# Altium schematic sheet Sheet2.SchDoc
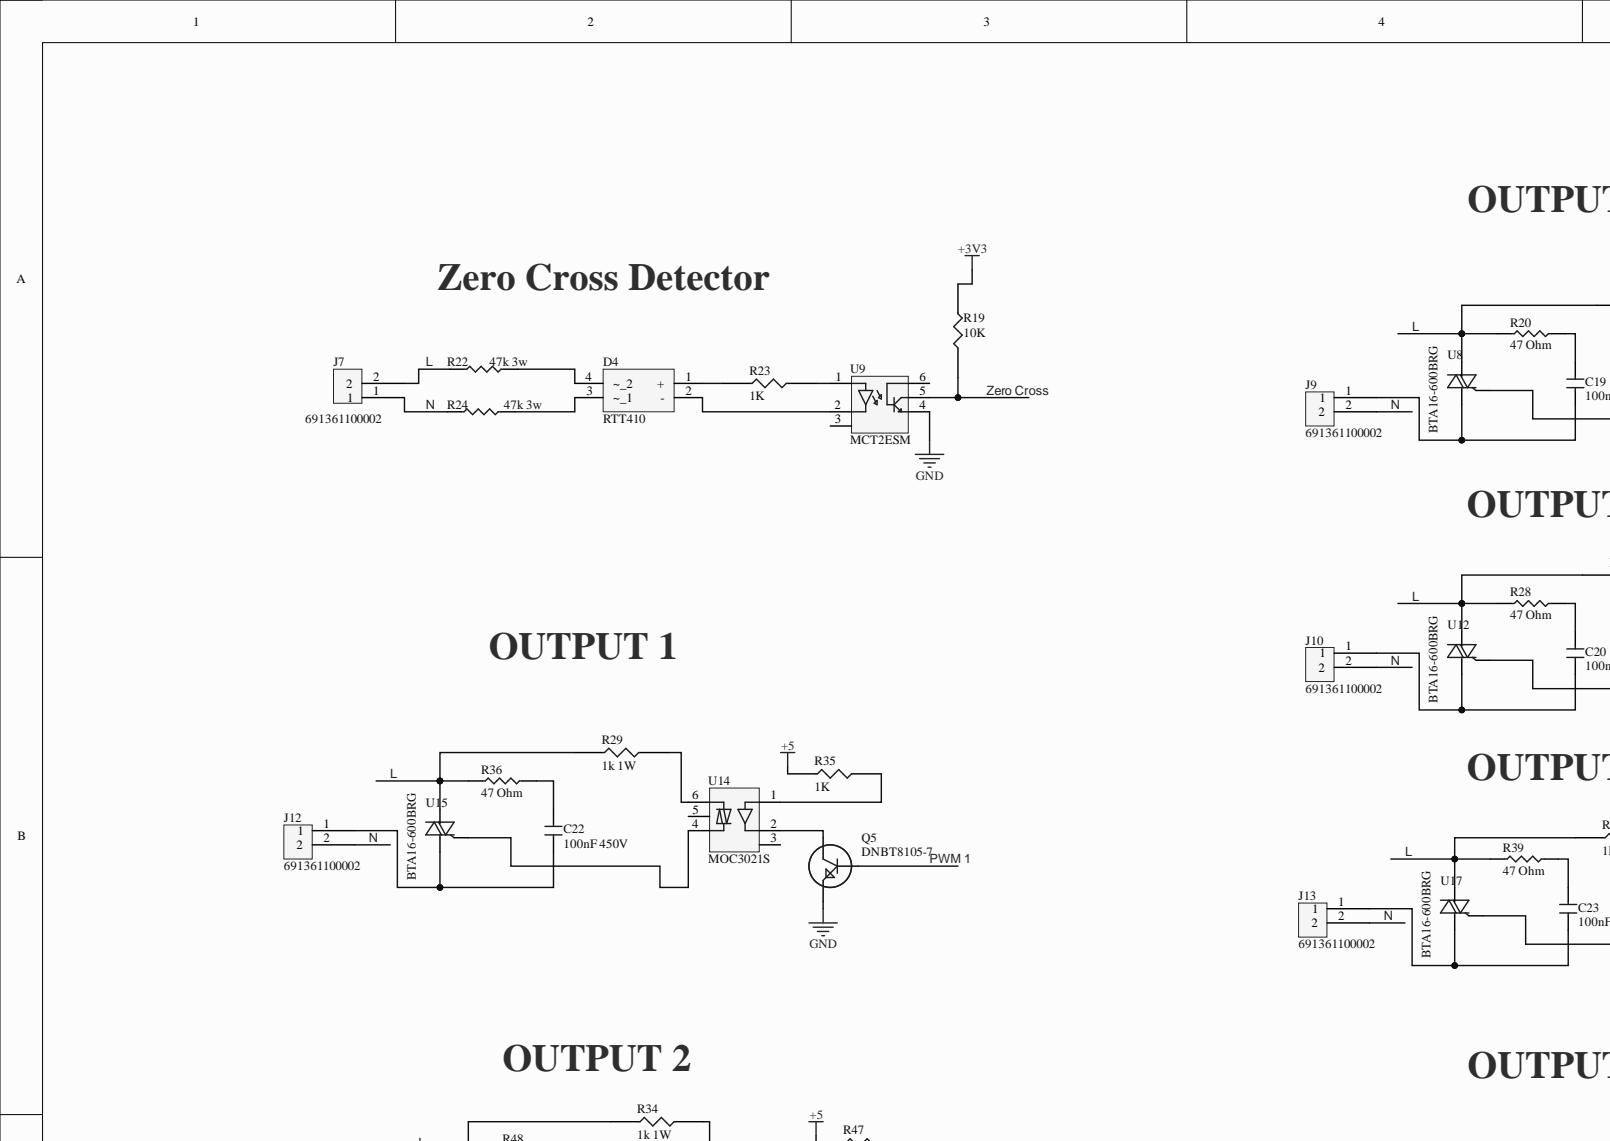
[diagram p0: Sheet2.SchDoc, top left]
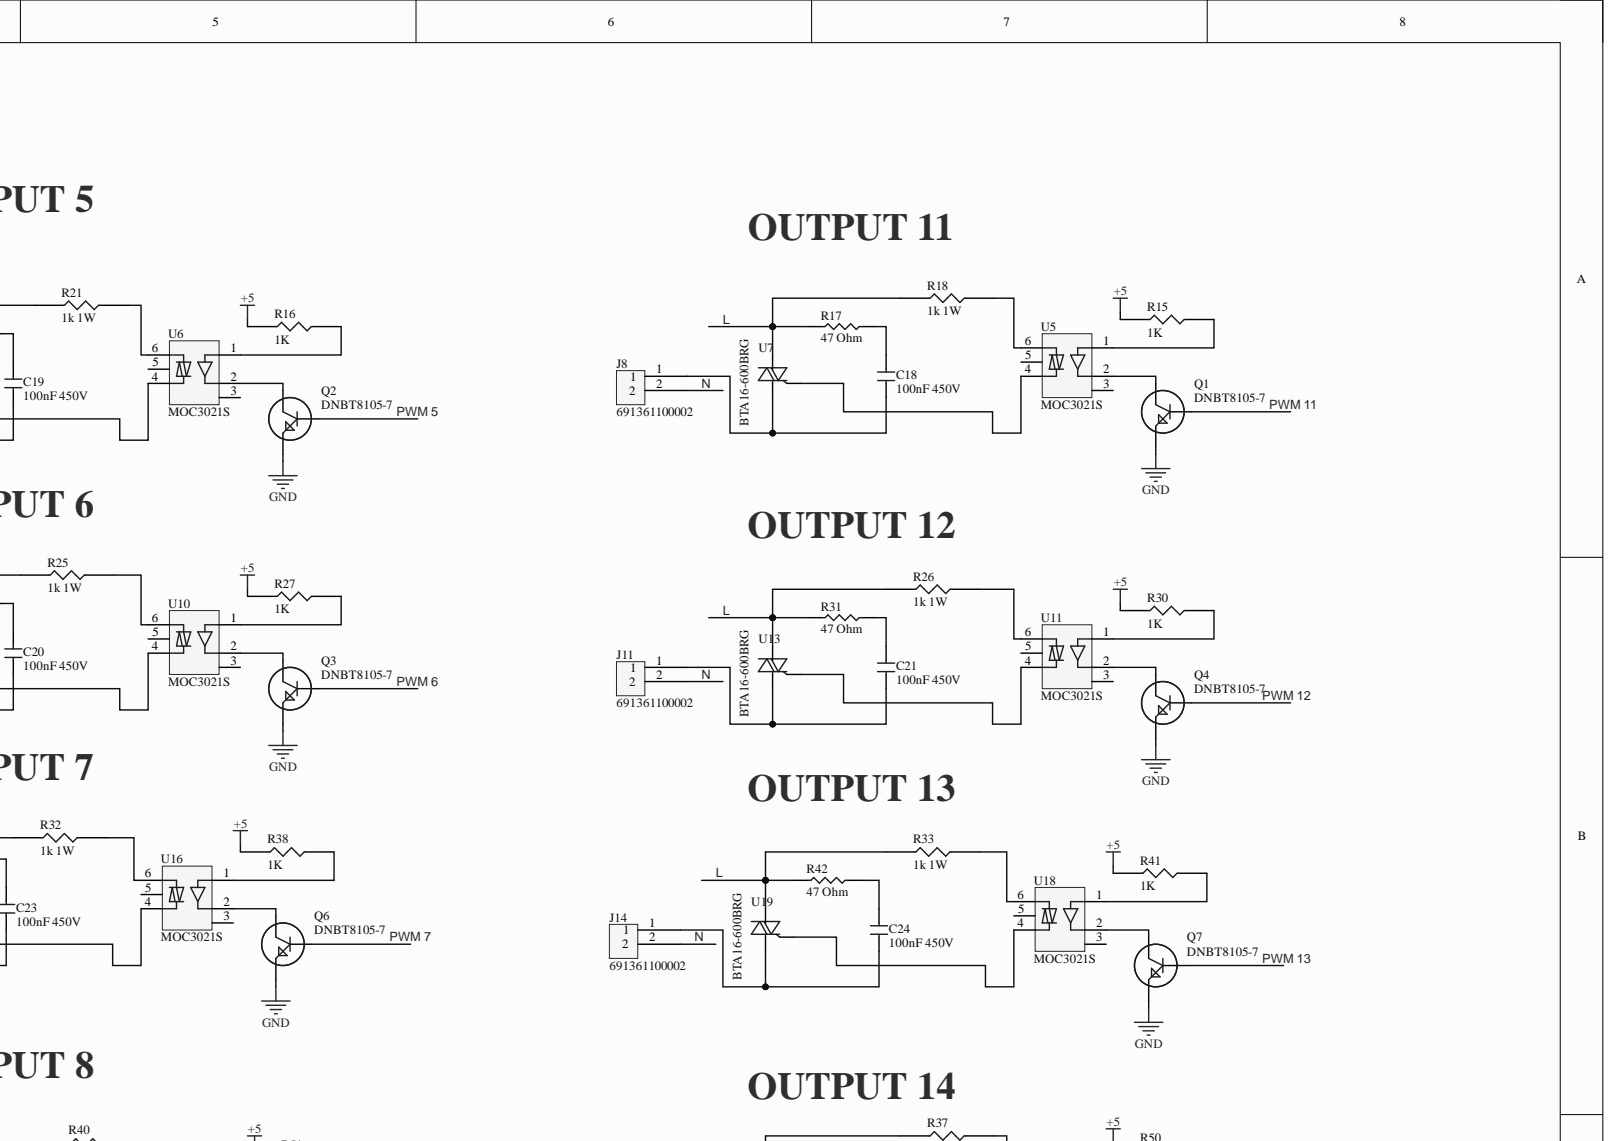
[diagram p1: Sheet2.SchDoc, top right]
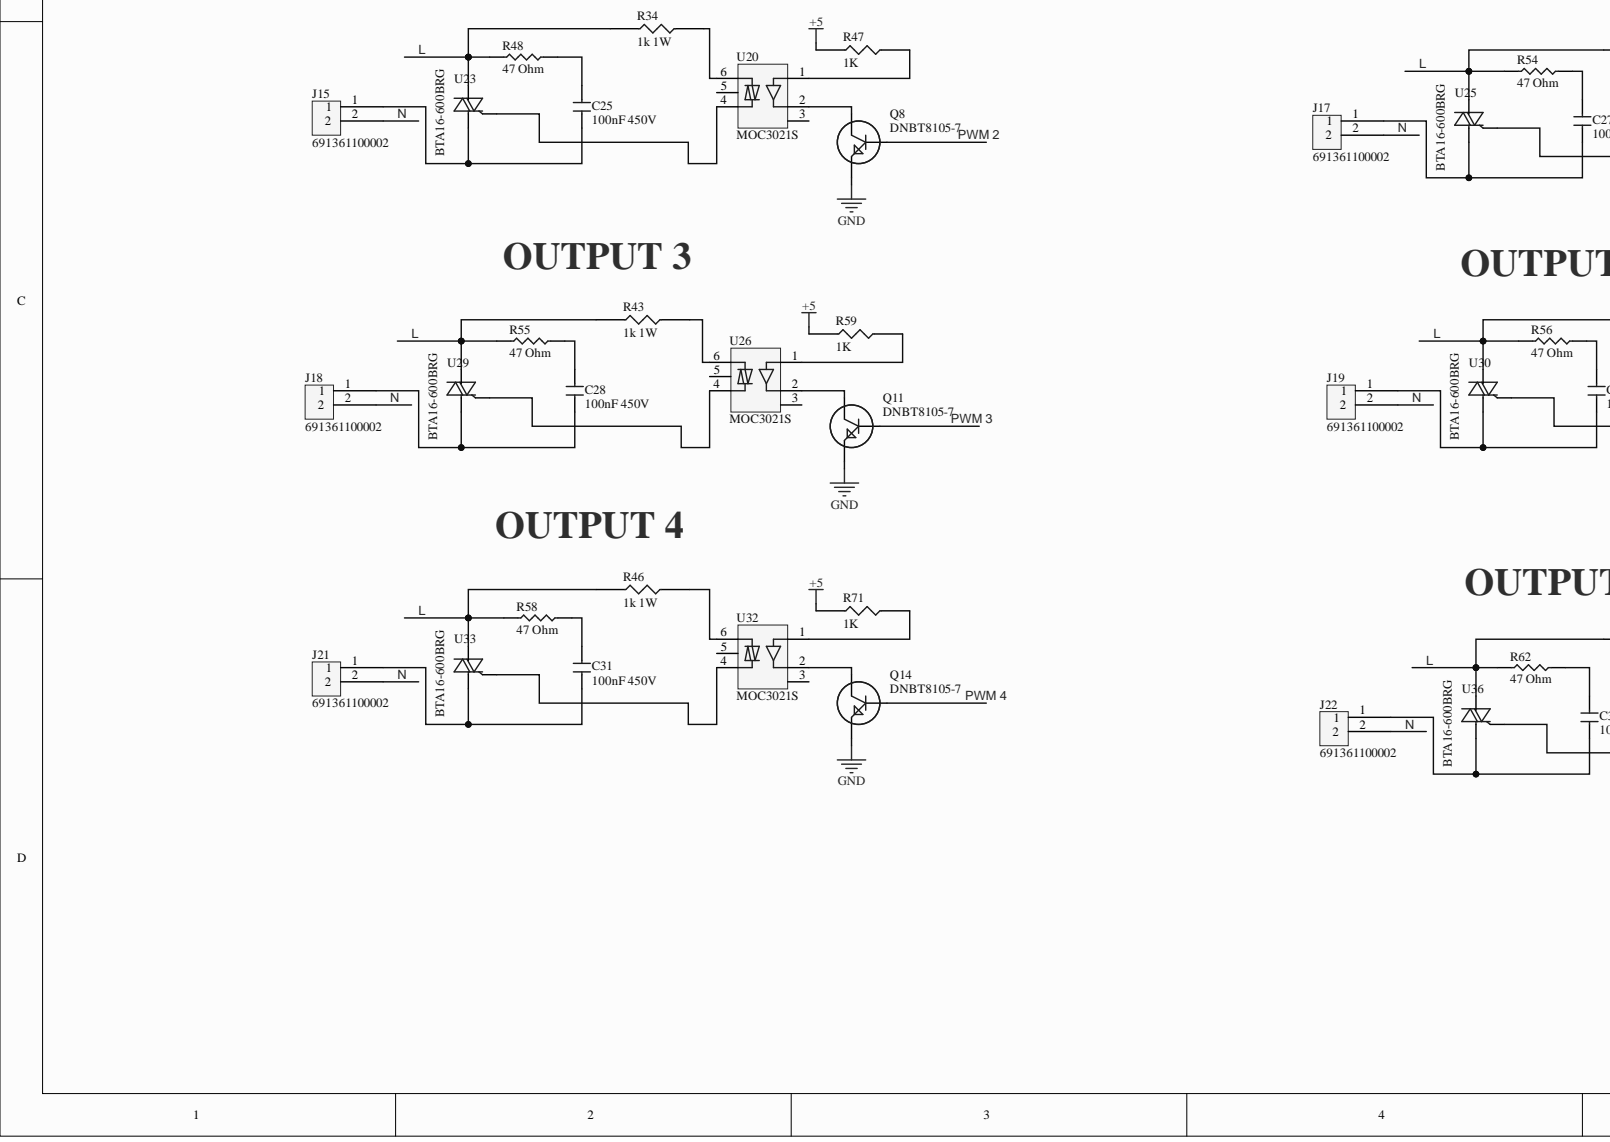
[diagram p2: Sheet2.SchDoc, bottom left]
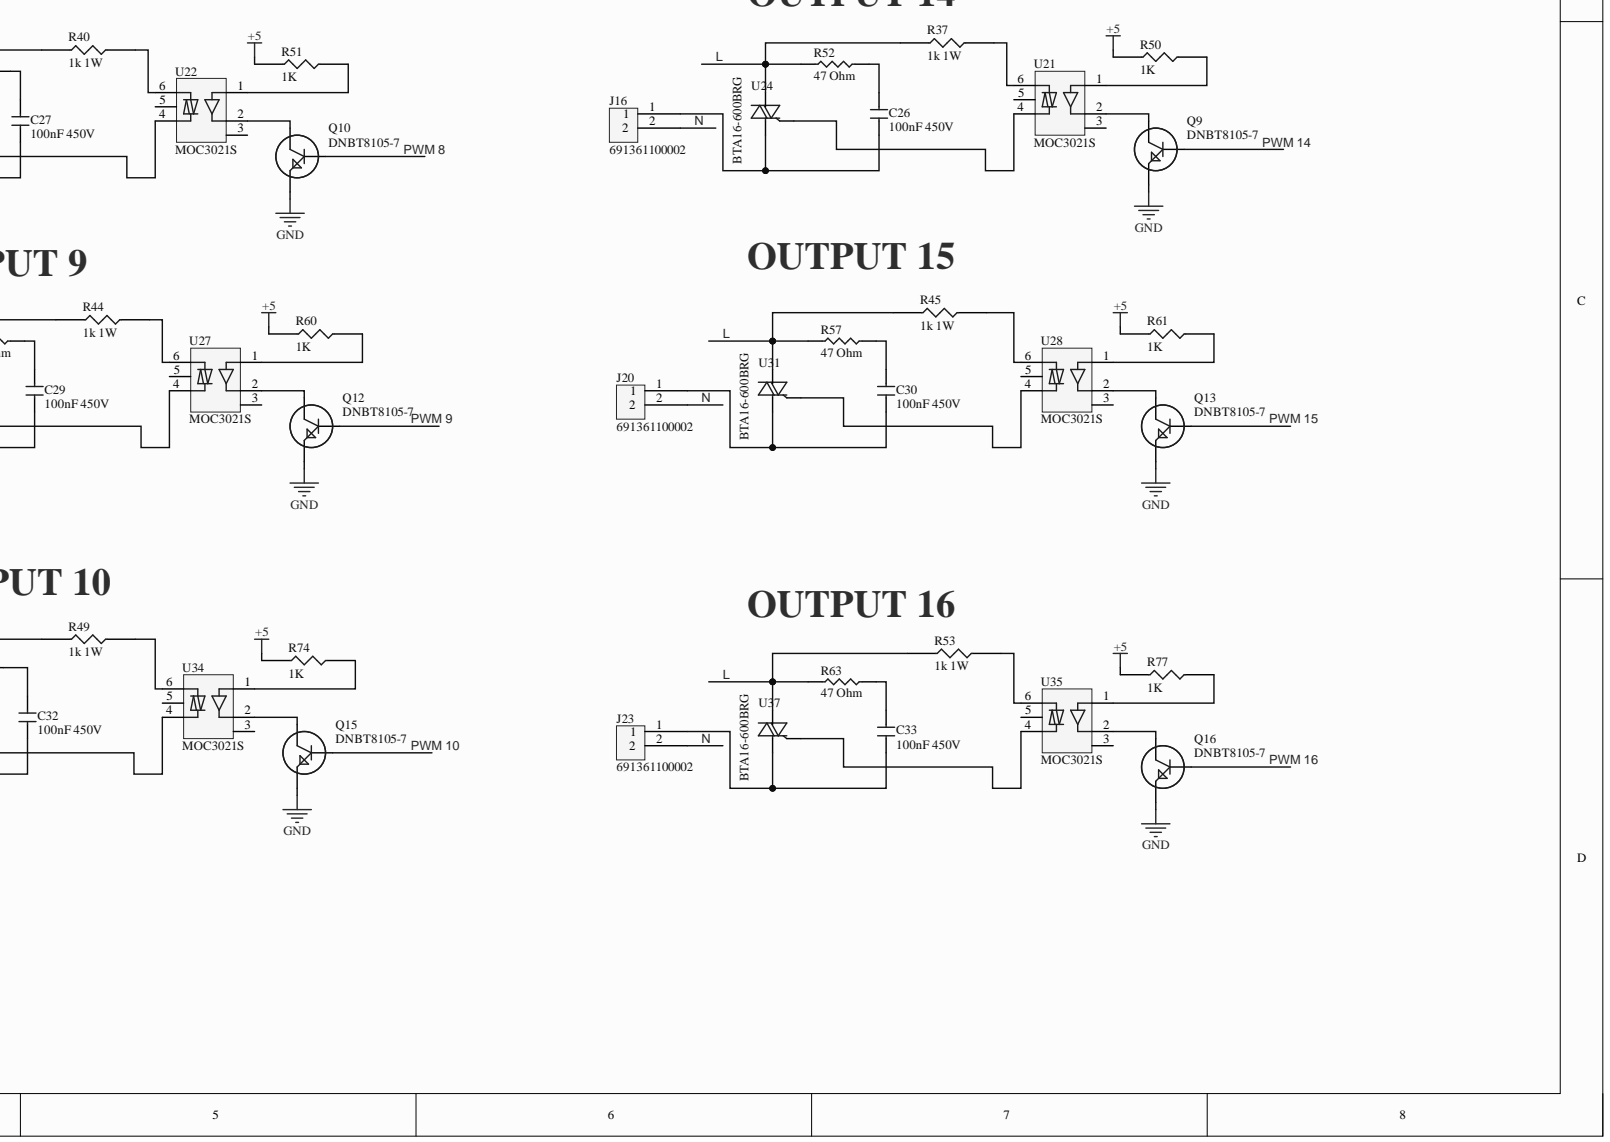
[diagram p3: Sheet2.SchDoc, bottom right]

## Parts
C18  100nF 450V  Capacitance: 100nF  Voltage Rating: 450V  [CAP 1206]
C19  100nF 450V  Capacitance: 100nF  Voltage Rating: 450V  [CAP 1206]
C20  100nF 450V  Capacitance: 100nF  Voltage Rating: 450V  [CAP 1206]
C21  100nF 450V  Capacitance: 100nF  Voltage Rating: 450V  [CAP 1206]
C22  100nF 450V  Capacitance: 100nF  Voltage Rating: 450V  [CAP 1206]
C23  100nF 450V  Capacitance: 100nF  Voltage Rating: 450V  [CAP 1206]
C24  100nF 450V  Capacitance: 100nF  Voltage Rating: 450V  [CAP 1206]
C25  100nF 450V  Capacitance: 100nF  Voltage Rating: 450V  [CAP 1206]
C26  100nF 450V  Capacitance: 100nF  Voltage Rating: 450V  [CAP 1206]
C27  100nF 450V  Capacitance: 100nF  Voltage Rating: 450V  [CAP 1206]
C28  100nF 450V  Capacitance: 100nF  Voltage Rating: 450V  [CAP 1206]
C29  100nF 450V  Capacitance: 100nF  Voltage Rating: 450V  [CAP 1206]
C30  100nF 450V  Capacitance: 100nF  Voltage Rating: 450V  [CAP 1206]
C31  100nF 450V  Capacitance: 100nF  Voltage Rating: 450V  [CAP 1206]
C32  100nF 450V  Capacitance: 100nF  Voltage Rating: 450V  [CAP 1206]
C33  100nF 450V  Capacitance: 100nF  Voltage Rating: 450V  [CAP 1206]
D4  RTT410  [RT410]
J7  691361100002  [Screw Terminal 3.5mm]
J8  691361100002  [Screw Terminal 3.5mm]
J9  691361100002  [Screw Terminal 3.5mm]
J10  691361100002  [Screw Terminal 3.5mm]
J11  691361100002  [Screw Terminal 3.5mm]
J12  691361100002  [Screw Terminal 3.5mm]
J13  691361100002  [Screw Terminal 3.5mm]
J14  691361100002  [Screw Terminal 3.5mm]
J15  691361100002  [Screw Terminal 3.5mm]
J16  691361100002  [Screw Terminal 3.5mm]
J17  691361100002  [Screw Terminal 3.5mm]
J18  691361100002  [Screw Terminal 3.5mm]
J19  691361100002  [Screw Terminal 3.5mm]
J20  691361100002  [Screw Terminal 3.5mm]
J21  691361100002  [Screw Terminal 3.5mm]
J22  691361100002  [Screw Terminal 3.5mm]
J23  691361100002  [Screw Terminal 3.5mm]
Q1  DNBT8105-7  [SOT 223 3]
Q2  DNBT8105-7  [SOT 223 3]
Q3  DNBT8105-7  [SOT 223 3]
Q4  DNBT8105-7  [SOT 223 3]
Q5  DNBT8105-7  [SOT 223 3]
Q6  DNBT8105-7  [SOT 223 3]
Q7  DNBT8105-7  [SOT 223 3]
Q8  DNBT8105-7  [SOT 223 3]
Q9  DNBT8105-7  [SOT 223 3]
Q10  DNBT8105-7  [SOT 223 3]
Q11  DNBT8105-7  [SOT 223 3]
Q12  DNBT8105-7  [SOT 223 3]
Q13  DNBT8105-7  [SOT 223 3]
Q14  DNBT8105-7  [SOT 223 3]
Q15  DNBT8105-7  [SOT 223 3]
Q16  DNBT8105-7  [SOT 223 3]
R15  1K  [R_0805]
R16  1K  [R_0805]
R17  47 Ohm  [R 1206]
R18  1k 1W  [R_0805]
R19  10K  [R_0805]
R20  47 Ohm  [R 1206]
R21  1k 1W  [R_0805]
R22  47k 3w  [R 2512]
R23  1K  [R_0805]
R24  47k 3w  [R 2512]
R25  1k 1W  [R_0805]
R26  1k 1W  [R_0805]
R27  1K  [R_0805]
R28  47 Ohm  [R 1206]
R29  1k 1W  [R_0805]
R30  1K  [R_0805]
R31  47 Ohm  [R 1206]
R32  1k 1W  [R_0805]
R33  1k 1W  [R_0805]
R34  1k 1W  [R_0805]
R35  1K  [R_0805]
R36  47 Ohm  [R 1206]
R37  1k 1W  [R_0805]
R38  1K  [R_0805]
R39  47 Ohm  [R 1206]
R40  1k 1W  [R_0805]
R41  1K  [R_0805]
R42  47 Ohm  [R 1206]
R43  1k 1W  [R_0805]
R44  1k 1W  [R_0805]
R45  1k 1W  [R_0805]
R46  1k 1W  [R_0805]
R47  1K  [R_0805]
R48  47 Ohm  [R 1206]
R49  1k 1W  [R_0805]
R50  1K  [R_0805]
R51  1K  [R_0805]
R52  47 Ohm  [R 1206]
R53  1k 1W  [R_0805]
R54  47 Ohm  [R 1206]
R55  47 Ohm  [R 1206]
R56  47 Ohm  [R 1206]
R57  47 Ohm  [R 1206]
R58  47 Ohm  [R 1206]
R59  1K  [R_0805]
R60  1K  [R_0805]
R61  1K  [R_0805]
R62  47 Ohm  [R 1206]
R63  47 Ohm  [R 1206]
R71  1K  [R_0805]
R74  1K  [R_0805]
R77  1K  [R_0805]
U5  MOC3021S  [SMD 6]
U6  MOC3021S  [SMD 6]
U7  BTA16-600BRG  [BAT16]
U8  BTA16-600BRG  [BAT16]
U9  MCT2ESM  [SMD 6]
U10  MOC3021S  [SMD 6]
U11  MOC3021S  [SMD 6]
U12  BTA16-600BRG  [BAT16]
U13  BTA16-600BRG  [BAT16]
U14  MOC3021S  [SMD 6]
U15  BTA16-600BRG  [BAT16]
U16  MOC3021S  [SMD 6]
U17  BTA16-600BRG  [BAT16]
U18  MOC3021S  [SMD 6]
U19  BTA16-600BRG  [BAT16]
U20  MOC3021S  [SMD 6]
U21  MOC3021S  [SMD 6]
U22  MOC3021S  [SMD 6]
U23  BTA16-600BRG  [BAT16]
U24  BTA16-600BRG  [BAT16]
U25  BTA16-600BRG  [BAT16]
U26  MOC3021S  [SMD 6]
U27  MOC3021S  [SMD 6]
U28  MOC3021S  [SMD 6]
U29  BTA16-600BRG  [BAT16]
U30  BTA16-600BRG  [BAT16]
U31  BTA16-600BRG  [BAT16]
U32  MOC3021S  [SMD 6]
U33  BTA16-600BRG  [BAT16]
U34  MOC3021S  [SMD 6]
U35  MOC3021S  [SMD 6]
U36  BTA16-600BRG  [BAT16]
U37  BTA16-600BRG  [BAT16]

## Nets
+3V3: R19.1
+5: R15.2 R16.2 R27.2 R30.2 R35.2 R38.2 R41.2 R47.2 R50.2 R51.2 R59.2 R60.2 R61.2 R71.2 R74.2 R77.2
GND: Q1.2 Q2.2 Q3.2 Q4.2 Q5.2 Q6.2 Q7.2 Q8.2 Q9.2 Q10.2 Q11.2 Q12.2 Q13.2 Q14.2 Q15.2 Q16.2 U9.4
L: J7.2 R17.1 R18.1 R20.1 R21.1 R22.1 R25.1 R26.1 R28.1 R29.1 R31.1 R32.1 R33.1 R34.1 R36.1 R37.1 R39.1 R40.1 R42.1 R43.1 R44.1 R45.1 R46.1 R48.1 R49.1 R52.1 R53.1 R54.1 R55.1 R56.1 R57.1 R58.1 R62.1 R63.1 U7.2 U8.2 U12.2 U13.2 U15.2 U17.2 U19.2 U23.2 U24.2 U25.2 U29.2 U30.2 U31.2 U33.2 U36.2 U37.2
N: J7.1 J8.2 J9.2 J10.2 J11.2 J12.2 J13.2 J14.2 J15.2 J16.2 J17.2 J18.2 J19.2 J20.2 J21.2 J22.2 J23.2 R24.1
PWM 1: Q5.1
PWM 2: Q8.1
PWM 3: Q11.1
PWM 4: Q14.1
PWM 5: Q2.1
PWM 6: Q3.1
PWM 7: Q6.1
PWM 8: Q10.1
PWM 9: Q12.1
PWM 10: Q15.1
PWM 11: Q1.1
PWM 12: Q4.1
PWM 13: Q7.1
PWM 14: Q9.1
PWM 15: Q13.1
PWM 16: Q16.1
Zero Cross: R19.2 U9.5
NetC18_1: C18.1 J8.1 U7.1
NetC18_2: C18.2 R17.2
NetC19_1: C19.1 J9.1 U8.1
NetC19_2: C19.2 R20.2
NetC20_1: C20.1 J10.1 U12.1
NetC20_2: C20.2 R28.2
NetC21_1: C21.1 J11.1 U13.1
NetC21_2: C21.2 R31.2
NetC22_1: C22.1 J12.1 U15.1
NetC22_2: C22.2 R36.2
NetC23_1: C23.1 J13.1 U17.1
NetC23_2: C23.2 R39.2
NetC24_1: C24.1 J14.1 U19.1
NetC24_2: C24.2 R42.2
NetC25_1: C25.1 J15.1 U23.1
NetC25_2: C25.2 R48.2
NetC26_1: C26.1 J16.1 U24.1
NetC26_2: C26.2 R52.2
NetC27_1: C27.1 J17.1 U25.1
NetC27_2: C27.2 R54.2
NetC28_1: C28.1 J18.1 U29.1
NetC28_2: C28.2 R55.2
NetC29_1: C29.1 J19.1 U30.1
NetC29_2: C29.2 R56.2
NetC30_1: C30.1 J20.1 U31.1
NetC30_2: C30.2 R57.2
NetC31_1: C31.1 J21.1 U33.1
NetC31_2: C31.2 R58.2
NetC32_1: C32.1 J22.1 U36.1
NetC32_2: C32.2 R62.2
NetC33_1: C33.1 J23.1 U37.1
NetC33_2: C33.2 R63.2
NetD4_1: D4.1(+) R23.1
NetD4_2: D4.2(-) U9.2
NetD4_3: D4.3(~_1) R24.2
NetD4_4: D4.4(~_2) R22.2
NetQ1_3: Q1.3 U5.2
NetQ2_3: Q2.3 U6.2
NetQ3_3: Q3.3 U10.2
NetQ4_3: Q4.3 U11.2
NetQ5_3: Q5.3 U14.2
NetQ6_3: Q6.3 U16.2
NetQ7_3: Q7.3 U18.2
NetQ8_3: Q8.3 U20.2
NetQ9_3: Q9.3 U21.2
NetQ10_3: Q10.3 U22.2
NetQ11_3: Q11.3 U26.2
NetQ12_3: Q12.3 U27.2
NetQ13_3: Q13.3 U28.2
NetQ14_3: Q14.3 U32.2
NetQ15_3: Q15.3 U34.2
NetQ16_3: Q16.3 U35.2
NetR15_1: R15.1 U5.1
NetR16_1: R16.1 U6.1
NetR18_2: R18.2 U5.6
NetR21_2: R21.2 U6.6
NetR23_2: R23.2 U9.1
NetR25_2: R25.2 U10.6
NetR26_2: R26.2 U11.6
NetR27_1: R27.1 U10.1
NetR29_2: R29.2 U14.6
NetR30_1: R30.1 U11.1
NetR32_2: R32.2 U16.6
NetR33_2: R33.2 U18.6
NetR34_2: R34.2 U20.6
NetR35_1: R35.1 U14.1
NetR37_2: R37.2 U21.6
NetR38_1: R38.1 U16.1
NetR40_2: R40.2 U22.6
NetR41_1: R41.1 U18.1
NetR43_2: R43.2 U26.6
NetR44_2: R44.2 U27.6
NetR45_2: R45.2 U28.6
NetR46_2: R46.2 U32.6
NetR47_1: R47.1 U20.1
NetR49_2: R49.2 U34.6
NetR50_1: R50.1 U21.1
NetR51_1: R51.1 U22.1
NetR53_2: R53.2 U35.6
NetR59_1: R59.1 U26.1
NetR60_1: R60.1 U27.1
NetR61_1: R61.1 U28.1
NetR71_1: R71.1 U32.1
NetR74_1: R74.1 U34.1
NetR77_1: R77.1 U35.1
NetU5_4: U5.4 U7.3
NetU6_4: U6.4 U8.3
NetU10_4: U10.4 U12.3
NetU11_4: U11.4 U13.3
NetU14_4: U14.4 U15.3
NetU16_4: U16.4 U17.3
NetU18_4: U18.4 U19.3
NetU20_4: U20.4 U23.3
NetU21_4: U21.4 U24.3
NetU22_4: U22.4 U25.3
NetU26_4: U26.4 U29.3
NetU27_4: U27.4 U30.3
NetU28_4: U28.4 U31.3
NetU32_4: U32.4 U33.3
NetU34_4: U34.4 U36.3
NetU35_4: U35.4 U37.3

Not connected: U5 3 5; U6 3 5; U9 3 6; U10 3 5; U11 3 5; U14 3 5; U16 3 5; U18 3 5; U20 3 5; U21 3 5; U22 3 5; U26 3 5; U27 3 5; U28 3 5; U32 3 5; U34 3 5; U35 3 5

## Library data of the parts
C18-C33 = Cap 100 nf 450V: Multilayer Ceramic Capacitor, 0.1 uF, 450 V, ± 10%, X7T, 1206 [3216 Metric]; Manufacturer: TDK; Manufacturer Part Number: CGA5L4X7T2W104K160AA; Case Code (Imperial): 1206; Case Code (Metric): 3216; Case/Package: 1206; Category: Ceramic Capacitors; Component Kind: Standard; ComponentLink1Description: https://datasheet.ciiva.com/24216/mlcc-automotive-midvoltage-en-355485-24216934.pdf?src-supplier=Mouser; ComponentLink1URL: https://datasheet.ciiva.com/24216/mlcc-automotive-midvoltage-en-355485-24216934.pdf?src-supplier=Mouser; ComponentLink2Description: https://datasheet.ciiva.com/8079/1807242-8079356.pdf?src-supplier=Element14; ComponentLink2URL: https://datasheet.ciiva.com/8079/1807242-8079356.pdf?src-supplier=Element14; Depth: 1.6mm; Dielectric: X7T; Height: 1.6mm; Lead Free: Lead Free; Length: 3.2mm; Library Name: Component Schematic Library V1.SchLib; Max Operating Temperature: 125°C; Min Operating Temperature: -55°C; Mount: Surface Mount; Package Quantity: 2000; Packaging: Tape and Reel; Pricing 1: 10=0.47200, 100=0.44400, 250=0.41500, 500=0.39200, 1000=0.35400 (GBP); Ratings: AEC-Q200; Reach SVHC Compliant: Yes; Resistor Type: Ceramic; RoHS: Yes; RoHS Compliant: Yes; Series: CGA; Stock 1: 610; Supplier 1: RSComponents; Supplier Part Number 1: 9038849; Termination: SMD/SMT; Thickness: 0.075inch; Tolerance: 10%; Voltage Rating (DC): 450V; Weight: 0.000571oz; Width: 1.6mm
D4 = RTT410: Manufacturer: Diodes; Category: Uncategorized; Component Kind: Standard; Library Name: Component Schematic Library V1.SchLib; Manufacturer Part Number: RTT410; Pricing 1: 1500=0.10358, 3000=0.09986, 6000=0.09686, 9000=0.09403, 12000=0.09050, 15000=0.08908, 150000=0.08767 (USD); RoHS: No; Stock 1: 6000; Supplier 1: Avnet; Supplier Part Number 1: RTT410
J7-J23 = Screw Tarminal 3.5mm pitch: 3611 Series 3.5mm Pitch Straight Pluggable Term Block; Plug; Cable Mount; 2 Way; Manufacturer: Wurth Electronics; Current: 10A; Number of Contacts: 2; Number of Levels: 1; Pitch: 0.138inch; Stripping Length: -7mm; Voltage: 300V; Wire Gauge (Max): 26AWG; Wire Gauge (Min): 16AWG; Category: Terminal Blocks; Color: Green; Component Kind: Standard; ComponentLink1Description: https://datasheet.ciiva.com/7992/1442235-7992395.pdf?src-supplier=Element14; ComponentLink1URL: https://datasheet.ciiva.com/7992/1442235-7992395.pdf?src-supplier=Element14; ComponentLink2Description: https://datasheet.ciiva.com/796/6913611000xx-796028.pdf?src-supplier=Digi-Key; ComponentLink2URL: https://datasheet.ciiva.com/796/6913611000xx-796028.pdf?src-supplier=Digi-Key; Contact Material: Brass; Current Rating: 10A; Height: 11.1mm; Lead Free: Lead Free; Length: 15.8mm; Library Name: Component Schematic Library V1.SchLib; Manufacturer Part Number: 691361100002; Mount: Free Hanging; Number of Positions Per Level: 2; Package Quantity: 650; Packaging: Bulk; Pricing 1: 1=2.51400, 10=2.28700, 50=2.12400, 100=2.02300, 250=1.93500 (USD); REACH SVHC: Unknown; RoHS: Yes; RoHS Compliant: Yes; Series: WR-TBL Series 3611; Stock 1: 1; Supplier 1: RSComponents; Supplier Part Number 1: 8250806; Termination: Screw; Voltage Rating: 300V; Width: 3.5mm; Wire/Cable Gauge: 26AWG
Q1-Q16 = NPN 1A: TRANS NPN 60V 1A SOT23-3; Manufacturer: Diodes Zetex; Additional Feature: HIGH RELIABILITY; Collector Base Voltage (VCBO): 80V; Collector Emitter Saturation Voltage: 500mV; DC Current Gain-Min (hFE): 30; Element Configuration: Single; Emitter Base Voltage (VEBO): 5V; Frequency: 150MHz; Max Power Dissipation: 600mW; Number of Elements: 1; Number of Terminals/Pins: 3; Package Body Material: Plastic; Power Dissipation: 300mW; Time @ Peak Reflow Temperature-Max (s): 10s; Transistor Application: SWITCHING; Transistor Element Material: SILICON; Case/Package: SOT-23; Category: BJTs; Collector Emitter Breakdown Voltage: 60V; Collector Emitter Voltage (VCEO): 60V; Collector-emitter Voltage-Max: 500mV; Component Kind: Standard; ComponentLink1Description: https://datasheet.ciiva.com/34302/1590567-34302596.pdf?src-supplier=Jameco; ComponentLink1URL: https://datasheet.ciiva.com/34302/1590567-34302596.pdf?src-supplier=Jameco; ComponentLink2Description: https://datasheet.ciiva.com/22711/ds30513-22711715.pdf?src-supplier=Element14; ComponentLink2URL: https://datasheet.ciiva.com/22711/ds30513-22711715.pdf?src-supplier=Element14; ComponentLink3Description: https://datasheet.ciiva.com/11201/ds30513-11201713.pdf?src-supplier=Avnet; ComponentLink3URL: https://datasheet.ciiva.com/11201/ds30513-11201713.pdf?src-supplier=Avnet; ComponentLink4Description: https://datasheet.ciiva.com/8029/ds30513-8029483.pdf?src-supplier=Element14; ComponentLink4URL: https://datasheet.ciiva.com/8029/ds30513-8029483.pdf?src-supplier=Element14; Contact Plating: Tin,Matte; Current Rating: 1A; Gain Bandwidth Product: 150MHz; Height: 1mm; hFE Min: 30; JESD-30 Code: R-PDSO-G3; Lead Free: Lead Free; Length: 2.9mm; Library Name: Component Schematic Library V1.SchLib; Manufacturer Part Number: DNBT8105-7; Max Breakdown Voltage: 60V; Max Collector Current: 1A; Max Operating Temperature: 150°C; Min Operating Temperature: -55°C; Moisture Sensitivity Level: 1; Mount: Surface Mount; Number of Pins: 3; Package Quantity: 3000; Package Shape: Rectangular; Packaging: Tape and Reel; Peak Reflow Temperature (Cel): 260°C; Polarity: NPN; Pricing 1: 50=0.36000, 600=0.17300, 1500=0.16800 (USD); Radiation Hardening: No; REACH SVHC: No SVHC; RoHS: Yes; RoHS Compliant: Yes; Series: -; Stock 1: 1; Supplier 1: RSComponents; Supplier Part Number 1: 7114923P; Surface Mount: Yes; Terminal Finish: Tin; Terminal Form: Gull Wing; Terminal Position: DUAL; Transition Frequency: 150MHz; Voltage Rating (DC): 60V; Weight: 0.000282oz; Width: 1.3mm
R15 R16 R23 R27 R30 R35 R38 R41 R47 R50 R51 R59-R61 R71 R74 R77 = RES_1_K_0805: 贴片电阻 1000Ω ± 1.00% 0.125W ± 100ppm/℃ 0805; Manufacturer: Yageo; Manufacturer Part Number: RC0805FR-071KL; Max Power Dissipation: 125mW; Resistance: 1kR; Resistance Tolerance: 1%; Temperature Coefficient: 100ppm/°C; Voltage Rating: 150V; Case Code (Imperial): 0805; Case Code (Metric): 2012; Case/Package: 0805; Category: Chip SMD Resistors; Component Kind: Standard; ComponentLink1Description: https://datasheet.ciiva.com/7941/1686128-7941719.pdf?src-supplier=Element14; ComponentLink1URL: https://datasheet.ciiva.com/7941/1686128-7941719.pdf?src-supplier=Element14; ComponentLink2Description: https://datasheet.ciiva.com/46385/444164-46385020.pdf?src-supplier=Element14; ComponentLink2URL: https://datasheet.ciiva.com/46385/444164-46385020.pdf?src-supplier=Element14; ComponentLink3Description: https://datasheet.ciiva.com/2456/pyu-r-int-thick-7-2456976.pdf?src-supplier=Digi-Key; ComponentLink3URL: https://datasheet.ciiva.com/2456/pyu-r-int-thick-7-2456976.pdf?src-supplier=Digi-Key; ComponentLink4Description: https://datasheet.ciiva.com/2456/pyu-r-int-thick-7-2456977.pdf?src-supplier=Digi-Key; ComponentLink4URL: https://datasheet.ciiva.com/2456/pyu-r-int-thick-7-2456977.pdf?src-supplier=Digi-Key; ComponentLink5Description: https://datasheet.ciiva.com/2456/pyu-r-int-thick-7-2456975.pdf?src-supplier=Digi-Key; ComponentLink5URL: https://datasheet.ciiva.com/2456/pyu-r-int-thick-7-2456975.pdf?src-supplier=Digi-Key; ComponentLink6Description: https://datasheet.ciiva.com/16850/rc0805-16850409.pdf?src-supplier=Future+Electronics; ComponentLink6URL: https://datasheet.ciiva.com/16850/rc0805-16850409.pdf?src-supplier=Future+Electronics; Construction: Rectangular; Depth: 1.25mm; Features: Moisture Resistant; Height: 0.024inch; Lead Free: Lead Free; Length: 0.079inch; Library Name: Component Schematic Library V1.SchLib; Max Operating Temperature: 155°C; Military Standard: Not; Min Operating Temperature: -55°C; Number of Pins: 2; Number of Terminations: 2; Package Quantity: 1; Packaging: Tape and Reel; Power Rating: 125mW; Pricing 1: 5000=0.00390 (USD); REACH SVHC: Unknown; Resistor Type: Thick Film; RoHS: Yes; RoHS Compliant: Yes; Series: RC; Size Code: 0805; Stock 1: 10195000; Supplier 1: Future Electronics; Supplier Part Number 1: 8126013; Termination: SMD/SMT; Tolerance: 1%; Voltage Rating (DC): 150V; Width: 0.049inch
R17 R20 R28 R31 R36 R39 R42 R48 R52 R54-R58 R62 R63 = Res 47 oHm 1/2 W: Res Thick Film 1206 47 Ohm 1% 1/2W ±200ppm/°C Molded SMD Paper T/R; Manufacturer: TE Connectivity; Manufacturer Part Number: CRGH1206F47R; Max Power Dissipation: 500mW; Number of Resistors: 1; Resistance: 47R; Voltage Rating: 200V; Case Code (Imperial): 1206; Case Code (Metric): 3216; Case/Package: 1206; Category: Chip SMD Resistors; Component Kind: Standard; ComponentLink1Description: http://farnell.com//datasheets/1755795.pdf?src-supplier=Farnell; ComponentLink1URL: http://farnell.com//datasheets/1755795.pdf?src-supplier=Farnell; ComponentLink2Description: http://www.farnell.com/datasheets/1755795.pdf?src-supplier=Farnell; ComponentLink2URL: http://www.farnell.com/datasheets/1755795.pdf?src-supplier=Farnell; ComponentLink3Description: https://www.te.com/commerce/DocumentDelivery/DDEController?Action=srchrtrv&amp;DocNm=1773204-1&amp;DocType=DS&amp;DocLang=English&src-supplier=Digi-Key; ComponentLink3URL: https://www.te.com/commerce/DocumentDelivery/DDEController?Action=srchrtrv&amp;DocNm=1773204-1&amp;DocType=DS&amp;DocLang=English&src-supplier=Digi-Key; ComponentLink4Description: http://www.farnell.com/datasheets/1755795.pdf?src-supplier=Element14; ComponentLink4URL: http://www.farnell.com/datasheets/1755795.pdf?src-supplier=Element14; ComponentLink5Description: http://www.te.com/commerce/DocumentDelivery/DDEController?Action=srchrtrv&DocNm=1309350_PASSIVE_COMPONENT&DocType=CS&DocLang=English&src-supplier=Digi-Key; ComponentLink5URL: http://www.te.com/commerce/DocumentDelivery/DDEController?Action=srchrtrv&DocNm=1309350_PASSIVE_COMPONENT&DocType=CS&DocLang=English&src-supplier=Digi-Key; ELV: Compliant; Library Name: Component Schematic Library V1.SchLib; Max Operating Temperature: 155°C; Min Operating Temperature: -55°C; Mount: Surface Mount; Number of Terminations: 2; Packaging: Tape and Reel; Power Rating: 500mW; Pricing 1: 1=0.17000, 10=0.14200, 100=0.05540, 1000=0.02318, 2500=0.02124 (USD); Reach SVHC Compliant: Yes; Resistance Tolerance: 1%; Resistor Type: Thick Film; RoHS: Yes; RoHS Compliant: Yes; Series: CRGH, Neohm; Stock 1: 107811; Supplier 1: Digi-Key; Supplier Part Number 1: A129227CT-ND; Temperature Coefficient: 200ppm/°C; Termination: Solder; Tolerance: 1%; Voltage Rating (DC): 200V
R18 R21 R25 R26 R29 R32-R34 R37 R40 R43-R46 R49 R53 = Res 1K 1W: Manufacturer: TE Connectivity; Manufacturer Part Number: RA73F2A1K0BTD; Category: Chip SMD Resistors; Component Kind: Standard; Library Name: Component Schematic Library V1.SchLib; Pricing 1: 1=1.46000, 10=1.29300, 100=0.93830, 1000=0.55466, 2500=0.54215 (USD); RoHS: No; Stock 1: 7849; Supplier 1: Digi-Key; Supplier Part Number 1: 1712-RA73F2A1K0BTDCT-ND
R19 = RES_10_K_0805: 贴片电阻 10000Ω ± 1.00% 0.125W ± 100ppm/℃ 0805; Manufacturer: Yageo; Manufacturer Part Number: RC0805FR-0710KL; Max Power Dissipation: 125mW; Resistance: 10kR; Resistance Tolerance: 1%; Temperature Coefficient: 100ppm/°C; Voltage Rating: 150V; Case Code (Imperial): 0805; Case Code (Metric): 2012; Case/Package: 0805; Category: Chip SMD Resistors; Component Kind: Standard; Construction: Rectangular; Depth: 1.25mm; Features: Moisture Resistant; Height: 0.024inch; Lead Free: Lead Free; Length: 2mm; Library Name: Component Schematic Library V1.SchLib; Max Operating Temperature: 155°C; Military Standard: Not; Min Operating Temperature: -55°C; Number of Terminations: 2; Package Quantity: 1; Packaging: Tape and Reel; Power Rating: 125mW; Pricing 1: 5000=0.00227, 10000=0.00224, 20000=0.00217 (USD); REACH SVHC: Unknown; Resistor Type: Thick Film; RoHS: Yes; RoHS Compliant: Yes; Series: RC; Size Code: 0805; Stock 1: 725000; Supplier 1: Avnet; Supplier Part Number 1: RC0805FR-0710KL; Technology: THICK FILM; Termination: SMD/SMT; Tolerance: 1%; Width: 0.049inch
R22 R24 = RES 47K 3W SMD: Res Thick Film 2512 47K Ohm 5% 3W ±100ppm/C Pad SMD T/R; Manufacturer: TE Connectivity CGS; Manufacturer Part Number: 352247KJT; Number of Resistors: 1; Resistance: 47kR; Temperature Coefficient: 100ppm/°C; Voltage Rating: 250V; Case Code (Imperial): 2512; Case Code (Metric): 6432; Case/Package: 2512; Category: Chip SMD Resistors; Component Kind: Standard; ComponentLink1Description: http://www.farnell.com/datasheets/2007092.pdf?src-supplier=Farnell; ComponentLink1URL: http://www.farnell.com/datasheets/2007092.pdf?src-supplier=Farnell; ComponentLink2Description: http://uk.rs-online.com/webdocs/142d/0900766b8142d139.pdf?src-supplier=RSComponents; ComponentLink2URL: http://uk.rs-online.com/webdocs/142d/0900766b8142d139.pdf?src-supplier=RSComponents; ComponentLink3Description: https://www.te.com/commerce/DocumentDelivery/DDEController?Action=srchrtrv&amp;DocNm=9-1773463-7&amp;DocType=DS&amp;DocLang=English&src-supplier=Digi-Key; ComponentLink3URL: https://www.te.com/commerce/DocumentDelivery/DDEController?Action=srchrtrv&amp;DocNm=9-1773463-7&amp;DocType=DS&amp;DocLang=English&src-supplier=Digi-Key; ComponentLink4Description: http://www.farnell.com/datasheets/2007092.pdf?src-supplier=Element14; ComponentLink4URL: http://www.farnell.com/datasheets/2007092.pdf?src-supplier=Element14; Depth: 3.2mm; Features: Automotive AEC-Q200; Height: 1.1mm; Length: 6.35mm; Library Name: Component Schematic Library V1.SchLib; Max Operating Temperature: 155°C; Max Power Dissipation: 3W; Min Operating Temperature: -55°C; Mount: Surface Mount; Number of Terminations: 2; Packaging: Tape and Reel; Power Rating: 3W; Pricing 1: 1=0.45000 (USD); Ratings: AEC-Q200; Resistance Tolerance: 5%; Resistor Type: Thick Film,Power resistor; RoHS: Yes; RoHS Compliant: Yes; Series: 3522, CGS; Stock 1: 32; Supplier 1: Avnet; Supplier Part Number 1: 84Y5180; Technology: THICK FILM; Termination: Solder; Tolerance: 5%; Voltage Rating (DC): 250V
U5 U6 U10 U11 U14 U16 U18 U20-U22 U26-U28 U32 U34 U35 = MOC3021S: MOC302x Series 1 Channel 400 V 5000 Vrms Surface Mount Triac Optocoupler - SMD-6; Manufacturer: Vishay Lite-On; Approvals: UL,CSA,VDE,TUV,CQC; Forward Current: 50mA; Forward Voltage: 1.15V; Hold Current: 250uA; Isolation Voltage: 5kV; Max Input Voltage: 1.5V; Number of Channels: 1; Output Voltage: 400V; Power Dissipation: 330mW; Reverse Breakdown Voltage: 6V; Turn-On Delay Time: 20us; Case/Package: SMD/SMT; Category: Optocouplers; Component Kind: Standard; ComponentLink1Description: https://datasheet.ciiva.com/15118/getdatasheetpartid-237228-15118280.pdf?src-supplier=Verical; ComponentLink1URL: https://datasheet.ciiva.com/15118/getdatasheetpartid-237228-15118280.pdf?src-supplier=Verical; ComponentLink2Description: https://datasheet.ciiva.com/25620/0900766b80de6ded-25620538.pdf?src-supplier=RSComponents; ComponentLink2URL: https://datasheet.ciiva.com/25620/0900766b80de6ded-25620538.pdf?src-supplier=RSComponents; ComponentLink3Description: https://datasheet.ciiva.com/25769/0900766b80de6ded-25769902.pdf?src-supplier=Allied+Electronics; ComponentLink3URL: https://datasheet.ciiva.com/25769/0900766b80de6ded-25769902.pdf?src-supplier=Allied+Electronics; ComponentLink4Description: https://datasheet.ciiva.com/2093/s-110-moc3020thrumoc3023series-2093402.pdf?src-supplier=Digi-Key; ComponentLink4URL: https://datasheet.ciiva.com/2093/s-110-moc3020thrumoc3023series-2093402.pdf?src-supplier=Digi-Key; Library Name: Component Schematic Library V1.SchLib; Manufacturer Part Number: MOC3021S; Max Input Current: 50mA; Max Operating Temperature: 100°C; Min Operating Temperature: -40°C; Mount: Surface Mount; Nominal Input Voltage: 1.15V; Number of Circuits: 1; Number of Elements: 1; Number of Pins: 6; Package Quantity: 65; Packaging: Rail/Tube; Pricing 1: 65=0.31800 (GBP); Radiation Hardening: No; RoHS: Yes; RoHS Compliant: Yes; Series: -; Stock 1: 5; Supplier 1: RSComponents; Supplier Part Number 1: 1689686
U7 U8 U12 U13 U15 U17 U19 U23-U25 U29-U31 U33 U36 U37 = Triac: TRIAC Standard 600V 16A Through Hole TO-220AB; Component Kind: Standard; Library Name: Component Schematic Library V1.SchLib
U9 = MCT2ESM: DC-IN 1-CH Transistor With Base DC-OUT 6-Pin PDIP SMD; Manufacturer: ON Semiconductor / Fairchild; Collector Emitter Saturation Voltage: 400mV; Fall Time: 1.5us; Forward Current: 60mA; Forward Voltage: 1.25V; Input Type: DC; Isolation Voltage: 7.5kV; Max Collector Current: 50mA; Max Output Voltage: 30V; Max Power Dissipation: 250mW; Number of Channels: 1; Reverse Breakdown Voltage: 3V; Rise Time: 2us; Case/Package: SMD/SMT; Category: Optocouplers; Collector Emitter Voltage (VCEO): 400mV; Collector-emitter Voltage-Max: 400mV; Component Kind: Standard; ComponentLink1Description: https://datasheet.ciiva.com/2087/mct2em-2087121.pdf?src-supplier=Digi-Key; ComponentLink1URL: https://datasheet.ciiva.com/2087/mct2em-2087121.pdf?src-supplier=Digi-Key; Lead Free: Lead Free; Library Name: Component Schematic Library V1.SchLib; Manufacturer Part Number: MCT2ESM; Max Input Current: 60mA; Max Operating Temperature: 100°C; Min Operating Temperature: -55°C; Mount: Surface Mount; Number of Circuits: 1; Number of Elements: 1; Number of Pins: 6; Output Current per Channel: 50mA; Output Voltage: 30V; Package Quantity: 1000; Packaging: Bulk; Power Dissipation: 250mW; Pricing 1: 1=0.72000, 10=0.46900, 100=0.30730, 1000=0.24263, 2000=0.22646, 5000=0.21837, 10000=0.21514, 25000=0.21028 (USD); RoHS: Yes; RoHS Compliant: Yes; Series: -; Stock 1: 971; Supplier 1: Digi-Key; Supplier Part Number 1: MCT2ESM-ND; Weight: 0.81g
